# Altium schematic sheet carrier_card_s3.SchDoc
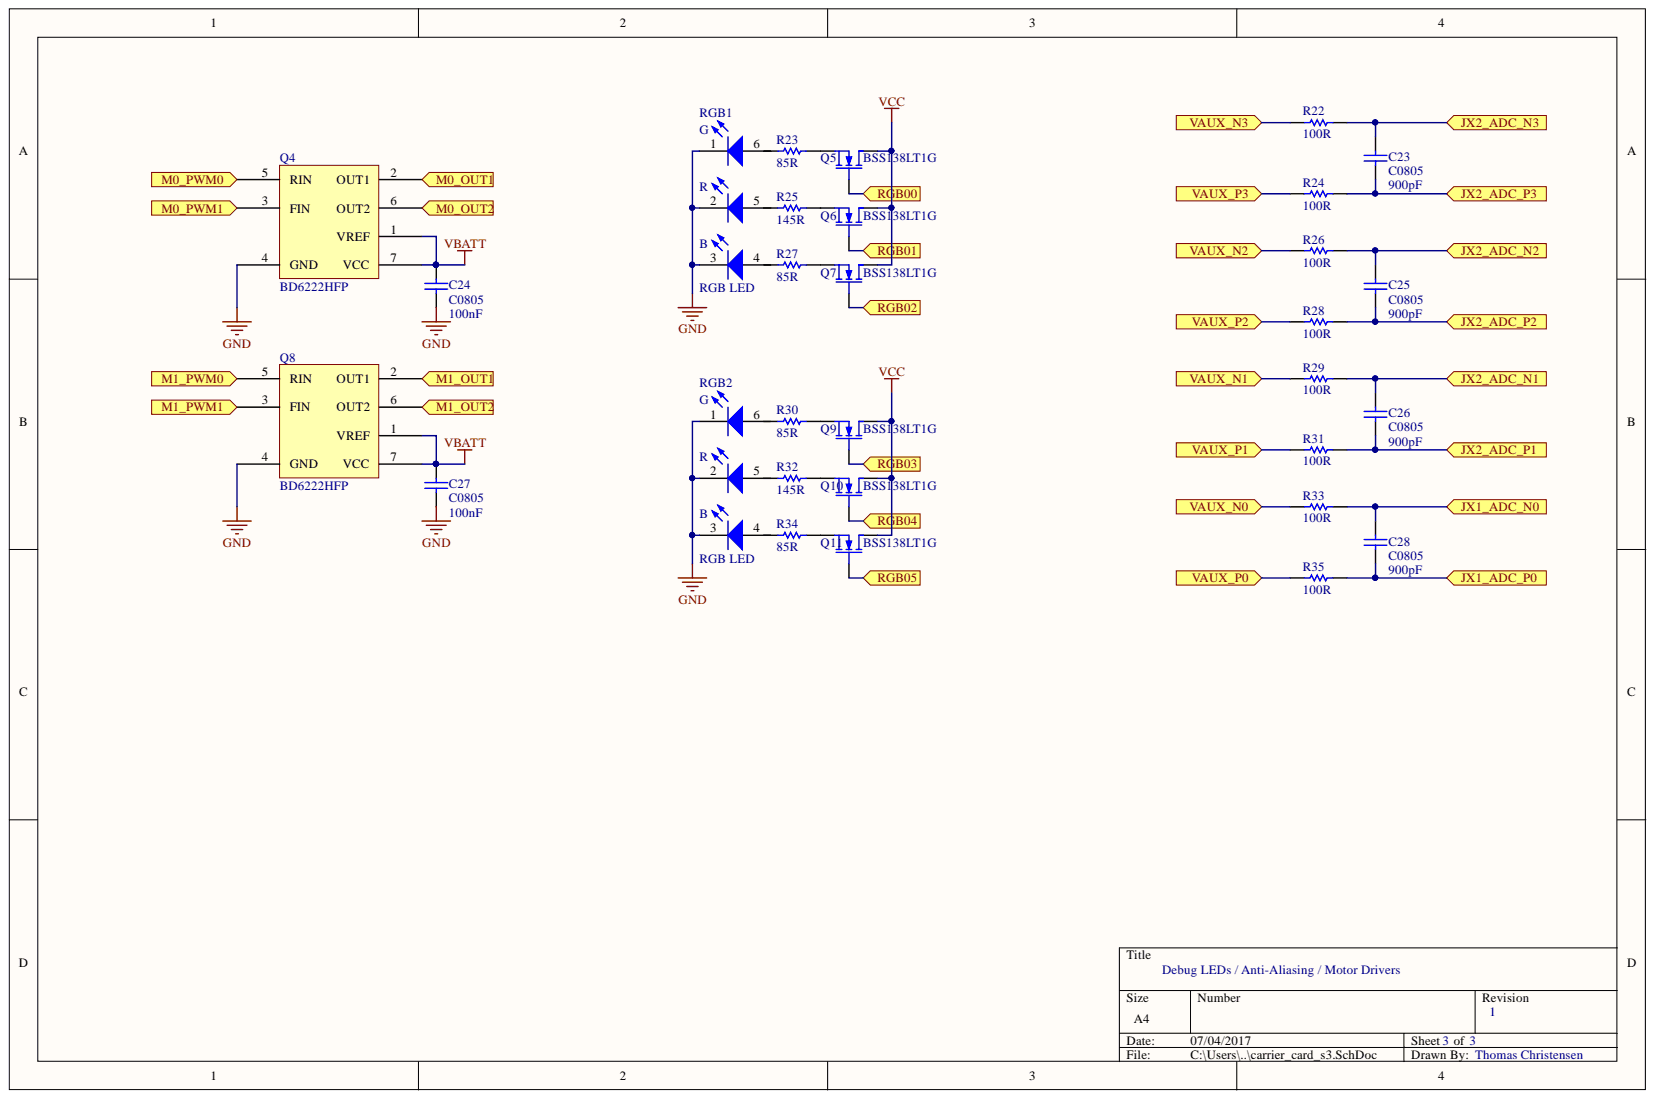
[diagram p0: carrier_card_s3.SchDoc]

## Parts
C23  C0805  value 900pF  [0805]
C24  C0805  value 100nF  [0805]
C25  C0805  value 900pF  [0805]
C26  C0805  value 900pF  [0805]
C27  C0805  value 100nF  [0805]
C28  C0805  value 900pF  [0805]
Q4  BD6222HFP  [HRP7_N]
Q5  BSS138LT1G  [E3]
Q6  BSS138LT1G  [E3]
Q7  BSS138LT1G  [E3]
Q8  BD6222HFP  [HRP7_N]
Q9  BSS138LT1G  [E3]
Q10  BSS138LT1G  [E3]
Q11  BSS138LT1G  [E3]
R22  100R  [ERA-0805]
R23  85R  [ERA-0805]
R24  100R  [ERA-0805]
R25  145R  [ERA-0805]
R26  100R  [ERA-0805]
R27  85R  [ERA-0805]
R28  100R  [ERA-0805]
R29  100R  [ERA-0805]
R30  85R  [ERA-0805]
R31  100R  [ERA-0805]
R32  145R  [ERA-0805]
R33  100R  [ERA-0805]
R34  85R  [ERA-0805]
R35  100R  [ERA-0805]
RGB1  RGB LED  [RGB_LED]
RGB2  RGB LED  [RGB_LED]

## Ports
JX1_ADC_N0, JX1_ADC_P0, JX2_ADC_N1, JX2_ADC_N2, JX2_ADC_N3, JX2_ADC_P1, JX2_ADC_P2, JX2_ADC_P3, M0_OUT1, M0_OUT2, M0_PWM0, M0_PWM1, M1_OUT1, M1_OUT2, M1_PWM0, M1_PWM1, RGB00, RGB01, RGB02, RGB03, RGB04, RGB05, VAUX_N0, VAUX_N1, VAUX_N2, VAUX_N3, VAUX_P0, VAUX_P1, VAUX_P2, VAUX_P3

## Nets
GND: C24.1 C27.1 Q4.4(GND) Q8.4(GND) RGB1.1 RGB1.2 RGB1.3 RGB2.1 RGB2.2 RGB2.3
VBATT: C24.2 C27.2 Q4.1(VREF) Q4.7(VCC) Q8.1(VREF) Q8.7(VCC)
VCC: Q5.1 Q6.1 Q7.1 Q9.1 Q10.1 Q11.1
JX1_ADC_N0: C28.1 R33.2 ; port JX1_ADC_N0
JX1_ADC_P0: C28.2 R35.2 ; port JX1_ADC_P0
JX2_ADC_N1: C26.1 R29.2 ; port JX2_ADC_N1
JX2_ADC_N2: C25.1 R26.2 ; port JX2_ADC_N2
JX2_ADC_N3: C23.1 R22.2 ; port JX2_ADC_N3
JX2_ADC_P1: C26.2 R31.2 ; port JX2_ADC_P1
JX2_ADC_P2: C25.2 R28.2 ; port JX2_ADC_P2
JX2_ADC_P3: C23.2 R24.2 ; port JX2_ADC_P3
M0_OUT1: Q4.2(OUT1) ; port M0_OUT1
M0_OUT2: Q4.6(OUT2) ; port M0_OUT2
M0_PWM0: Q4.5(RIN) ; port M0_PWM0
M0_PWM1: Q4.3(FIN) ; port M0_PWM1
M1_OUT1: Q8.2(OUT1) ; port M1_OUT1
M1_OUT2: Q8.6(OUT2) ; port M1_OUT2
M1_PWM0: Q8.5(RIN) ; port M1_PWM0
M1_PWM1: Q8.3(FIN) ; port M1_PWM1
RGB00: Q5.2 ; port RGB00
RGB01: Q6.2 ; port RGB01
RGB02: Q7.2 ; port RGB02
RGB03: Q9.2 ; port RGB03
RGB04: Q10.2 ; port RGB04
RGB05: Q11.2 ; port RGB05
VAUX_N0: R33.1 ; port VAUX_N0
VAUX_N1: R29.1 ; port VAUX_N1
VAUX_N2: R26.1 ; port VAUX_N2
VAUX_N3: R22.1 ; port VAUX_N3
VAUX_P0: R35.1 ; port VAUX_P0
VAUX_P1: R31.1 ; port VAUX_P1
VAUX_P2: R28.1 ; port VAUX_P2
VAUX_P3: R24.1 ; port VAUX_P3
NetQ5_3: Q5.3 R23.2
NetQ6_3: Q6.3 R25.2
NetQ7_3: Q7.3 R27.2
NetQ9_3: Q9.3 R30.2
NetQ10_3: Q10.3 R32.2
NetQ11_3: Q11.3 R34.2

Not connected: R23 1; R25 1; R27 1; R30 1; R32 1; R34 1; RGB1 4 5 6; RGB2 4 5 6

## Library data of the parts
C23-C28 = C0805: Ceramic Chip Capacitor - Standard; Note: Footprint corresponds to dimensions for bulk cassette packaging. This case size has tightened tolerances.; Code_IPC: 2012[0805]; Code_JEITA: 0805; Component Kind: Standard; ComponentLink1Description: Manufacturer Link; ComponentLink1URL: http://www.kemet.com/; ComponentLink2Description: Datasheet; ComponentLink2URL: http://www.kemet.com/kemet/web/homepage/kechome.nsf/vapubfilesname/F3102TA.pdf/$file/F3102TA.pdf; DatasheetDocument: Mar-2001; LatestRevisionDate: 19-Mar-2004; LatestRevisionNote: Silkscreen size reduced.; Library Name: KEMET Chip Capacitor.IntLib; PackageDocument: Mar-2001; PackageReference: 0805; Published: 17-Jul-2002; Signal Integrity: C0805; Simulation: CAP; Publisher: Altium Limited
Q5-Q7 Q9-Q11 = BSS138LT1G: N-Channel MOSFET; Component Kind: Standard; LatestRevisionDate: 17-Jul-2002; LatestRevisionNote: Re-released for DXP Platform.; PackageDescription: TO, Flat Index; 3 In-Line, Axial Leads; Body Dia. 4.6mm; Lead Dia. 0.5 mm (max); PackageReference: E3; PackageVersion: 1995/1996; Published: 8-Jun-2000; Simulation: NMOS; Library Name: swarmbot_schematic_library.SchLib; Publisher: Altium Limited
R22-R35 = ERA6Y: Rectangular Thick Film Chip Resistor, 10 Ohm to 1M Ohm Range, 0.1% and 0.5% Tolerance, 0805 Size, 0.1 W; Note: If flow soldering, adjust pad width of PCB Footprint to 0.7 - 0.8 times the width of the resistor.; Code_IPC: 2012 [0805]; Component Kind: Standard; ComponentLink1Description: Manufacturer Link; ComponentLink1URL: http://www.panasonic.com/industrial/components/resistive_products.htm; ComponentLink2Description: Datasheet; ComponentLink2URL: http://www.panasonic.com/industrial/components/pdf/AOA0000CE8.pdf; LatestRevisionDate: 19-Mar-2004; LatestRevisionNote: Silkscreen size reduced.; Library Name: Panasonic Resistor.IntLib; PackageDocument: Mar-2003; PackageReference: ERA-0805; Published: 17-Dec-2003; Signal Integrity: Res; Simulation: RESISTOR; Publisher: Altium Limited
RGB1 RGB2 = LTRB R8SF: Component Kind: Standard; Library Name: swarmbot_schematic_library.SchLib
Q4 Q8 = BD6222HFP: Component Kind: Standard; Library Name: swarmbot_schematic_library.SchLib
